# Altium schematic sheet Temp_Measurements.SchDoc
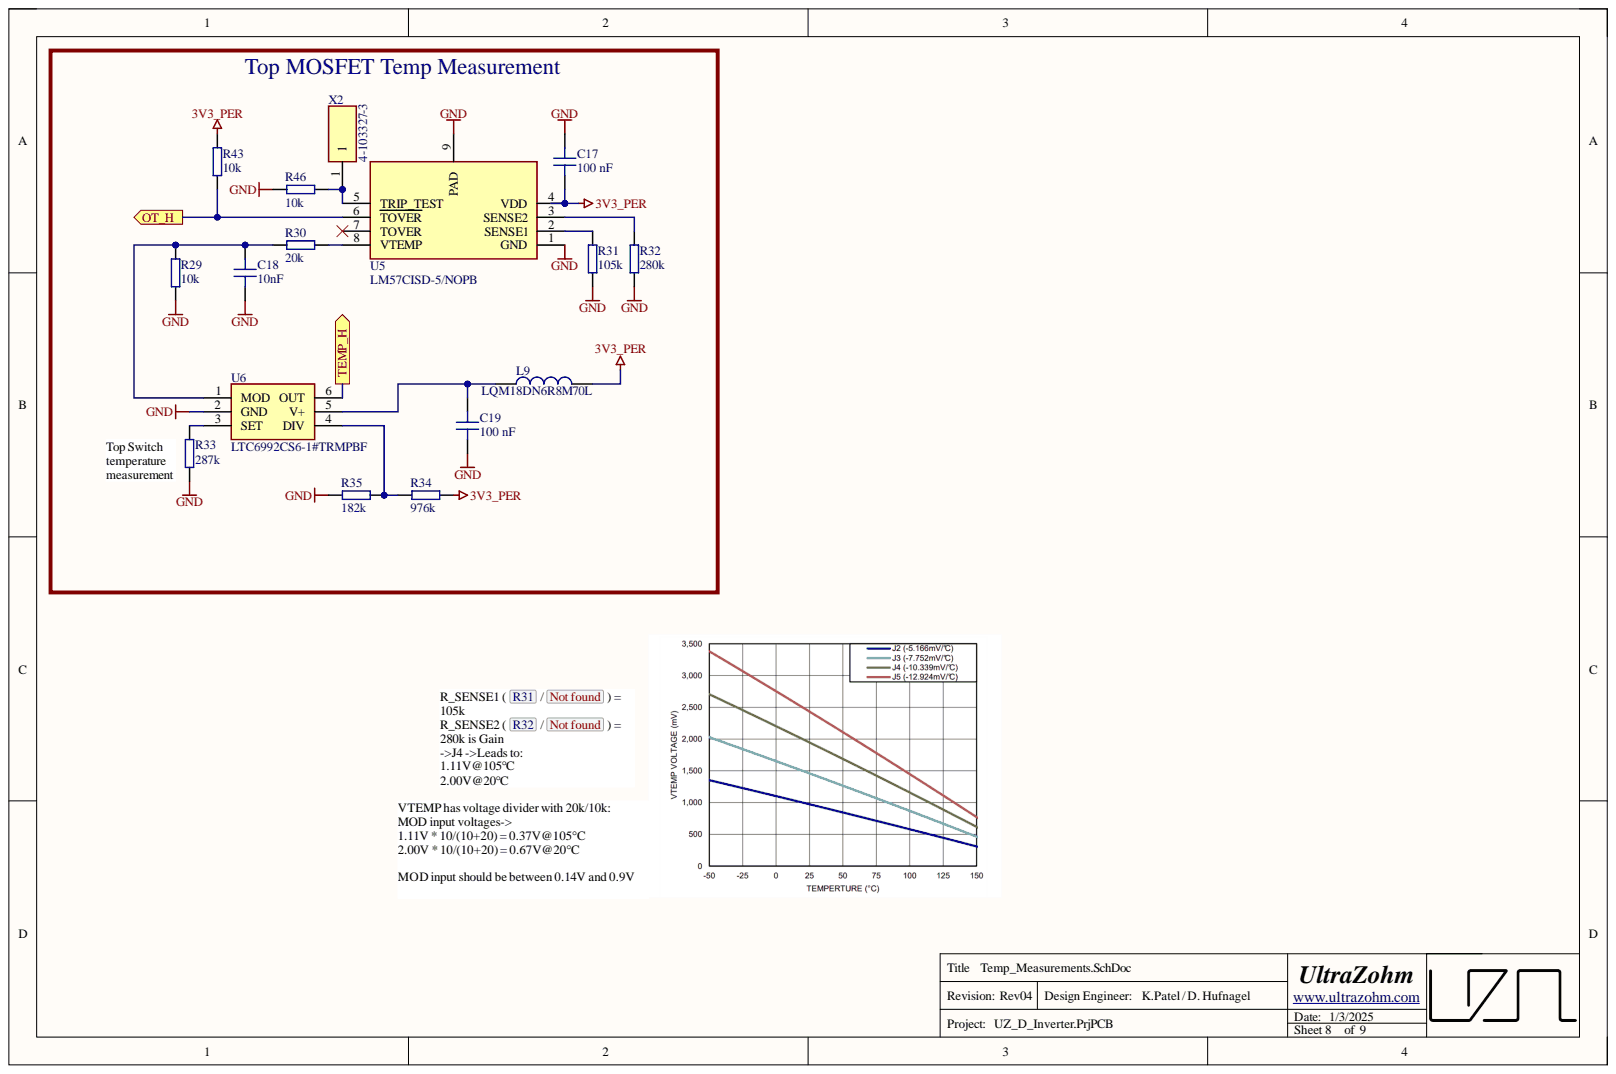
[diagram p0: Temp_Measurements.SchDoc]

## Parts
C17  100 nF  [C_0603]
C18  10nF  [C_0603]
C19  100 nF  [C_0603]
L9  LQM18DN6R8M70L  [INDC1608X95N]
R29  10k  [RES 0603_1608]
R30  20k  [RES 0603_1608]
R31  105k  [RES 0603_1608]
R32  280k  [RES 0603_1608]
R33  287k  [RES 0805_2012]
R34  976k  [RES 0603_1608]
R35  182k  [RES 0603_1608]
R43  10k  [RES 0603_1608]
R46  10k  [RES 0603_1608]
U5  LM57CISD-5/NOPB  [SON50P250X250X80-9N]
U6  LTC6992CS6-1#TRMPBF  [SOT95P280X100-6N]
X2  4-103327-3  [1_Pin_Header]

## Ports
OT_H, TEMP_H

## Nets
3V3_PER: C17.1 L9.2 R34.2 R43.1 U5.4(VDD)
GND: C17.2 C18.1 C19.1 R29.2 R31.1 R32.1 R33.1 R35.1 R46.2 U5.1(GND) U5.9(PAD) U6.2(GND)
OT_H: R43.2 U5.6(TOVER) ; port OT_H
TEMP_H: U6.6(OUT) ; port TEMP_H
NetC18_2: C18.2 R29.1 R30.1 U6.1(MOD)
NetC19_2: C19.2 L9.1 U6.5(V+)
NetR30_2: R30.2 U5.8(VTEMP)
NetR31_2: R31.2 U5.2(SENSE1)
NetR32_2: R32.2 U5.3(SENSE2)
NetR33_2: R33.2 U6.3(SET)
NetR34_1: R34.1 R35.2 U6.4(DIV)
NetR46_1: R46.1 U5.5(TRIP_TEST) X2.1

Not connected: U5 7(TOVER)

## Library data of the parts
C17 C19 = C1608X7S2A104KT000N: 100V 100nF X7S ±10% 0603 Multilayer Ceramic Capacitors MLCC - SMD/SMT ROHS; Manufacturer: TDK; Created from: AGeiger; Database Table Name: Capacitors - SMD; Voltage Rating: 100 VDC; Component Kind: Standard; Component Type: Capacitor 0603; ComponentLink1Description: Datasheet; ComponentLink1URL: https://datasheet.lcsc.com/lcsc/2304140030_TDK-C1608X7S2A104KT000N_C343025.pdf; Created On: 20.12.2020 13:54:13; Device Package: 0603; Last Updated: 14.12.2022 12:14:21; LCSC Part Number: C343025; Library Name: user.DbLib; Live Cycle Status: 1; Manufacturer Part Number: C1608X7S2A104KT000N; Mounting Type: SMD; Operating Temperature: -55 to 125°C; PartID: 148; Series: X7R; Stock number: not stored; Supplier 1: LCSC; Supplier Part Number 1: C343025; Tolerance: 10 %; Type: Capacitor; Value: 100 nF
C18 = CT41G-0603-2X1-50V-0.01μF-K(N): 50V 10nF 2X1 ±10%; Manufacturer: TORCH; Created from: KIlhan; Database Table Name: Capacitors - SMD; Type: Capacitor; Voltage Rating: 50 VDC; Component Kind: Standard; Component Type: Capacitor 0603; ComponentLink1Description: Datasheet; ComponentLink1URL: https://datasheet.lcsc.com/lcsc/1809291621_TORCH-CT41G-0603-2X1-50V-0-01-F-K-N_C136131.pdf; Created On: 05.07.2023 18:57:50; Device Package: 0603; Last Updated: 05.07.2023 18:57:50; LCSC Part Number: C136131; Library Name: user.DbLib; Live Cycle Status: 1; Manufacturer Part Number: CT41G-0603-2X1-50V-0.01μF-K(N); Mounting Type: SMD; Operating Temperature: -55 - 125°C; PartID: 130; Stock number: not stored; Supplier 1: LCSC; Supplier Part Number 1: C136131; Tolerance: 10 %; Value: 10nF
L9 = LQM18DN6R8M70L: 6.8µH, 120mA, 0603, 740mOhm, 20%; Manufacturer: Murata; MPN: B82464G4154M; Created from: DHufnagel; Database Table Name: Inductances - SMD; DCR: 320m; MF: EPCOS / TDK; Rated Current: 0.85 A; Type: fixed inductor; Value: 6.8uH; Component Kind: Standard; Component Type: SMD fixed inductor; ComponentLink1Description: Datasheet; ComponentLink1URL: https://www.mouser.de/datasheet/2/281/1/JELF243B_0086-2298241.pdf; Created On: 19.07.2022 15:47:17; DC current: 120mA; Device Package: SMD; Last Updated: 15.06.2023 16:03:16; LCSC Part Number: C1881372; Library Name: user.DbLib; Live Cycle Status: 1; Manufacturer Part Number: LQM18DN6R8M70L; Mounting Type: SMD; Operating Temperature: -40 - 125°C; PartID: 10; Series: LQM18DN_70; Stock number: not stored; Supplier 1: Mouser; Supplier 2: Digi-Key; Supplier Part Number 1: 81-LQM18DN6R8M70L; Supplier Part Number 2: 490-LQM18DN6R8M70LCT-ND
R29 R43 R46 = RK73B1JTTD103J: SMD resistor E24 series; Manufacturer: KOA Speer; Created from: MGremer; Database Table Name: Resistors - SMD; Tolerance: 5%; Component Kind: Standard; Component Type: SMD resistor; ComponentLink1Description: Datasheet; ComponentLink1URL: https://www.mouser.de/datasheet/2/219/RK73B-1825463.pdf; Created On: 14.12.2020 16:17:14; Device Package: 0603; Last Updated: 27.05.2022 14:04:22; LCSC Part Number: C25804; Library Name: user.DbLib; Live Cycle Status: 1; Manufacturer Part Number: RK73B1JTTD103J; Mounting Type: SMD; PartID: 98; Stock number: Labor SMD Container; Supplier 1: Mouser; Supplier Part Number 1: 660-RK73B1JTTD103J; Type: Resistor; Value: 10k
R30 = RK73B1JTTD203J: SMD resistor E24 series; Manufacturer: KOA Speer; Created from: MGremer; Database Table Name: Resistors - SMD; Tolerance: 5%; Component Kind: Standard; Component Type: SMD resistor; ComponentLink1Description: Datasheet; ComponentLink1URL: https://www.mouser.de/datasheet/2/219/RK73B-1825463.pdf; Created On: 14.12.2020 16:17:14; Device Package: 0603; Last Updated: 27.05.2022 13:42:48; LCSC Part Number: C4184; Library Name: user.DbLib; Live Cycle Status: 1; Manufacturer Part Number: RK73B1JTTD203J; Mounting Type: SMD; PartID: 105; Stock number: Labor SMD Container; Supplier 1: Mouser; Supplier Part Number 1: 660-RK73B1JTTD203J; Type: Resistor; Value: 20k
R31 = CR0603-FX-1053ELF: SMD resistor 1%, 50V, 100ppm; Manufacturer: Bourns; Created from: DHufnagel; Database Table Name: Resistors - SMD; Component Kind: Standard; Component Type: SMD resistor; ComponentLink1Description: Datasheet; ComponentLink1URL: https://www.mouser.de/datasheet/2/54/cr-1858361.pdf; Created On: 25.07.2022 08:26:35; Device Package: 0603; Last Updated: 21.02.2024 16:03:36; LCSC Part Number: C141685; Library Name: user.DbLib; Live Cycle Status: 1; Manufacturer Part Number: CR0603-FX-1053ELF; Mounting Type: SMD; PartID: 232; Stock number: not stored; Supplier 1: Mouser; Supplier 2: LCSC; Supplier Part Number 1: 652-CR0603-FX1053ELF; Supplier Part Number 2: C141685; Tolerance: 1%; Type: Resistor; Value: 105k
R32 = CR0603-FX-2803ELF: SMD resistor 1%, 50V, 100ppm; Manufacturer: Bourns; Created from: DHufnagel; Database Table Name: Resistors - SMD; Component Kind: Standard; Component Type: SMD resistor; ComponentLink1Description: Datasheet; ComponentLink1URL: https://www.mouser.de/datasheet/2/54/cr-1858361.pdf; Created On: 25.07.2022 08:26:35; Device Package: 0603; Last Updated: 21.02.2024 16:06:06; LCSC Part Number: C861797; Library Name: user.DbLib; Live Cycle Status: 1; Manufacturer Part Number: CR0603-FX-2803ELF; Mounting Type: SMD; PartID: 233; Stock number: not stored; Supplier 1: Mouser; Supplier 2: LCSC; Supplier Part Number 1: 652-CR0603-FX2803ELF; Supplier Part Number 2: C861797; Tolerance: 1%; Type: Resistor; Value: 280k
R33 = ERJ-6ENF2873V: SMD resistor 1%; Manufacturer: Panasonic; Created from: MGremer; Database Table Name: Resistors - SMD; Component Kind: Standard; Component Type: SMD resistor; ComponentLink1Description: Datasheet; ComponentLink1URL: https://www.mouser.de/datasheet/2/315/AOA0000C304-1149620.pdf; Created On: 14.12.2020 16:17:14; Device Package: 0805; Last Updated: 15.02.2023 18:07:29; LCSC Part Number: C228683; Library Name: user.DbLib; Live Cycle Status: 1; Manufacturer Part Number: ERJ-6ENF2873V; Mounting Type: SMD; PartID: 154; Stock number: not stored; Supplier 1: Mouser; Supplier Part Number 1: 667-ERJ-6ENF2873V; Tolerance: 1%; Type: Resistor; Value: 287k
R34 = CR0603-FX-9763ELF: SMD resistor 1%, 50V, 100ppm; Manufacturer: Bourns; Created from: DHufnagel; Database Table Name: Resistors - SMD; Component Kind: Standard; Component Type: SMD resistor; ComponentLink1Description: Datasheet; ComponentLink1URL: https://www.mouser.de/datasheet/2/54/cr-1858361.pdf; Created On: 25.07.2022 08:26:35; Device Package: 0603; Last Updated: 15.02.2023 18:14:05; LCSC Part Number: C162980; Library Name: user.DbLib; Live Cycle Status: 1; Manufacturer Part Number: CR0603-FX-9763ELF; Mounting Type: SMD; PartID: 234; Stock number: not stored; Supplier 1: Mouser; Supplier Part Number 1: 652-CR0603FX-9763ELF; Tolerance: 1%; Type: Resistor; Value: 976k
R35 = CR0603-FX-1823ELF: SMD resistor 1%, 50V, 100ppm; Manufacturer: Bourns; Created from: DHufnagel; Database Table Name: Resistors - SMD; Component Kind: Standard; Component Type: SMD resistor; ComponentLink1Description: Datasheet; ComponentLink1URL: https://www.mouser.de/datasheet/2/54/cr-1858361.pdf; Created On: 25.07.2022 08:26:35; Device Package: 0603; Last Updated: 15.02.2023 18:09:14; LCSC Part Number: C203368; Library Name: user.DbLib; Live Cycle Status: 1; Manufacturer Part Number: CR0603-FX-1823ELF; Mounting Type: SMD; PartID: 235; Stock number: not stored; Supplier 1: Mouser; Supplier Part Number 1: 652-CR0603FX-1823ELF; Tolerance: 1%; Type: Resistor; Value: 182k
U5 = LM57CISD-10/NOPB: Board Mount Temperature Sensor, -50 - 150°C; Manufacturer: Texas Instruments; Created from: DHufnagel; Database Table Name: Microcontrollers; Component Kind: Standard; Component Type: Temperature Sensors; ComponentLink1Description: Datasheet; ComponentLink1URL: https://www.ti.com/general/docs/suppproductinfo.tsp?distId=26&gotoUrl=https://www.ti.com/lit/gpn/lm57; Created On: 30.06.2022 08:21:10; Device Package: WSON-8; Last Updated: 14.06.2023 22:16:01; LCSC Part Number: C2864092; Library Name: user.DbLib; Live Cycle Status: 1; Manufacturer Part Number: LM57CISD-5/NOPB; Mounting Type: SMD; Operating Temperature: -50 - 150°C; PartID: 5; Stock number: not stored; Supplier 1: Mouser; Supplier 2: Digi-Key; Supplier Part Number 1: 926-LM57CISD-5/NOPB; Supplier Part Number 2: LM57CISD-5/NOPBCT-ND; Type: Temperature Sensor
U6 = LTC6992CS6-1#TRMPBF: Switching Controllers PWM with 0% to 100% Pulse Width Control; Manufacturer: Analog Devices; Created from: AGeiger; Database Table Name: Microcontrollers; Component Kind: Standard; Component Type: Switching Controllers; ComponentLink1Description: Datasheet; ComponentLink1URL: https://www.mouser.de/datasheet/2/609/LTC6992-1-6992-2-6992-3-6992-4-1852873.pdf; Created On: 20.07.2022 14:30:26; Device Package: SOT-23-6; Last Updated: 14.06.2023 22:16:01; LCSC Part Number: C581034; Library Name: user.DbLib; Live Cycle Status: 1; Manufacturer Part Number: LTC6992CS6-1#TRMPBF; Mounting Type: SMD; Operating Temperature: 0 to 70 °C; PartID: 11; Stock number: not stored; Supplier 1: Mouser; Supplier 2: LCSC; Supplier Part Number 1: 584-C6992CS6-1TRMPBF; Supplier Part Number 2: C581034; Type: Switching Controller
X2 = 4-103327-3: Pin Header, 2.54mm, 1 Pin; Manufacturer: TE Connectivity; Created from: DHufnagel; Database Table Name: Connectors - Pin Header; Number of positions: 1; Component Kind: Standard; Component Type: Pin Header; ComponentLink1Description: Datasheet; ComponentLink1URL: https://www.mouser.de/datasheet/2/418/NG_CD_103327_T_baseFilename-749242.pdf; Created On: 18.08.2022 09:37:37; Device Package: THT; Last Updated: 18.08.2022 09:41:31; Library Name: user.DbLib; Live Cycle Status: 1; Manufacturer Part Number: 4-103327-3; Mounting Type: THT; Number of rows: 1; Operating Temperature: -65 - 105 °C; PartID: 12; Series: AMPMODU MOD II; Stock number: not stored; Supplier 1: Mouser; Supplier Part Number 1: 571-4-103327-3; Type: Pin Header
